# Altium schematic sheet Power_Supply.SchDoc(Power_Supply)
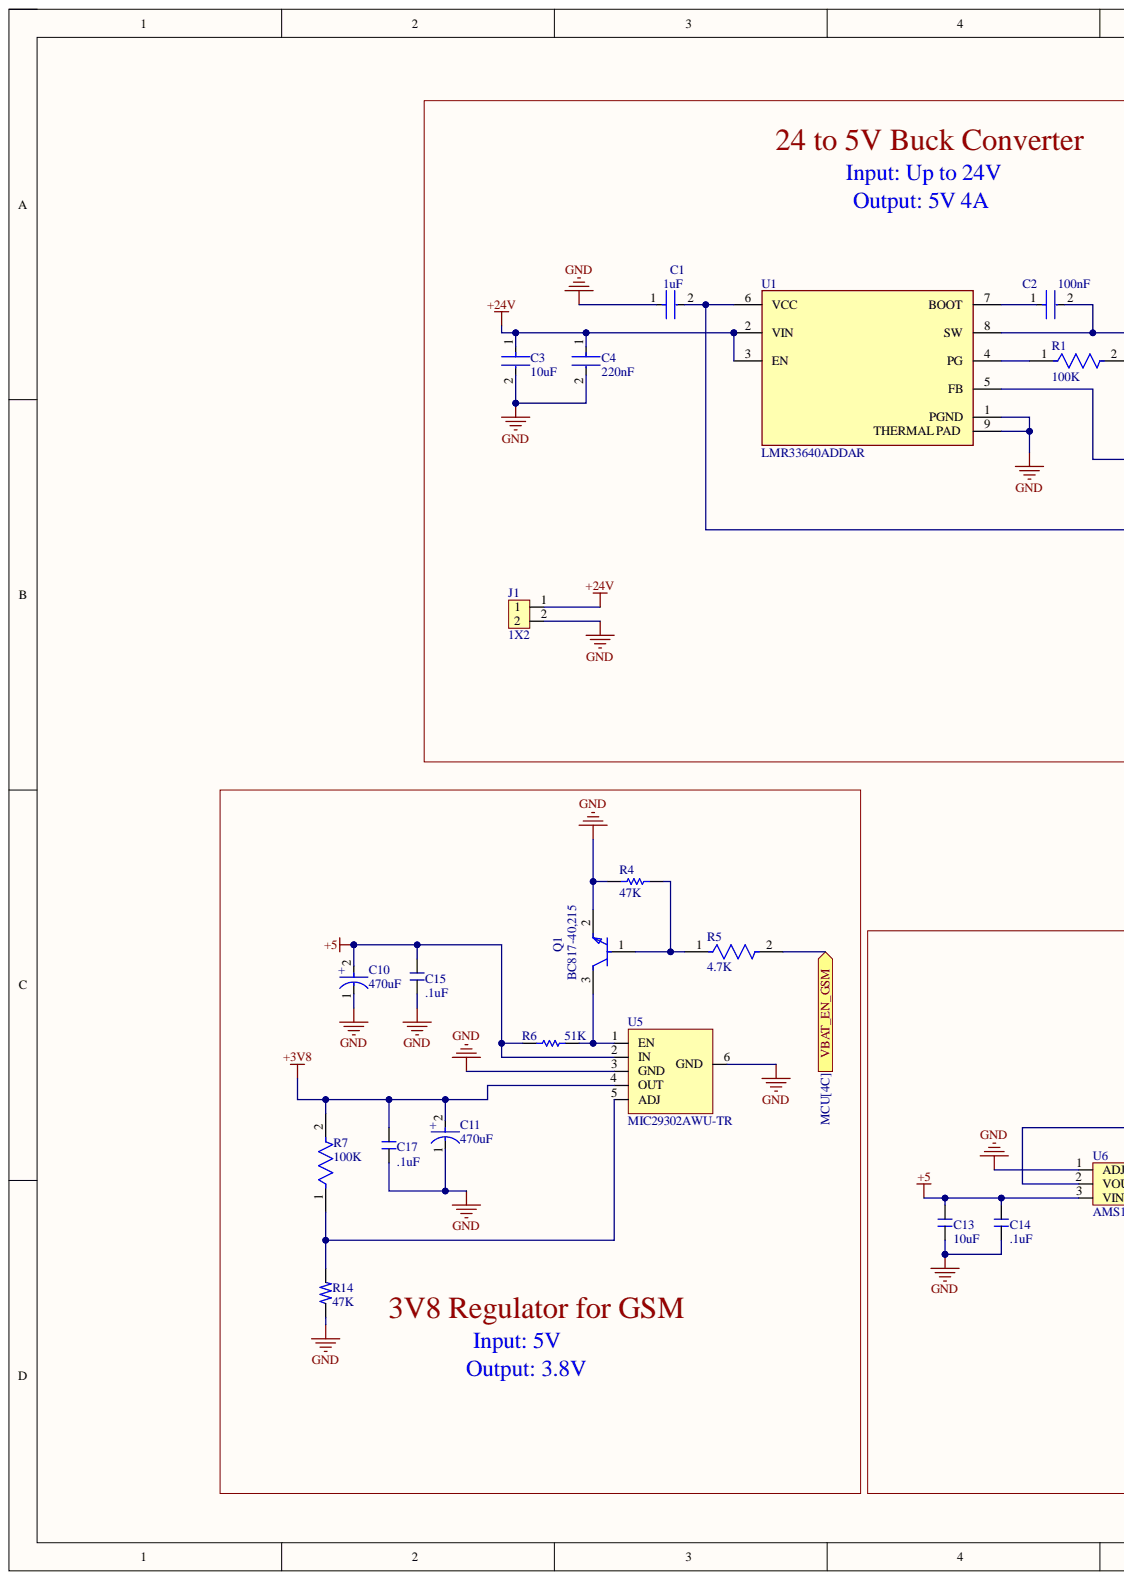
[diagram p0: Power_Supply.SchDoc, left]
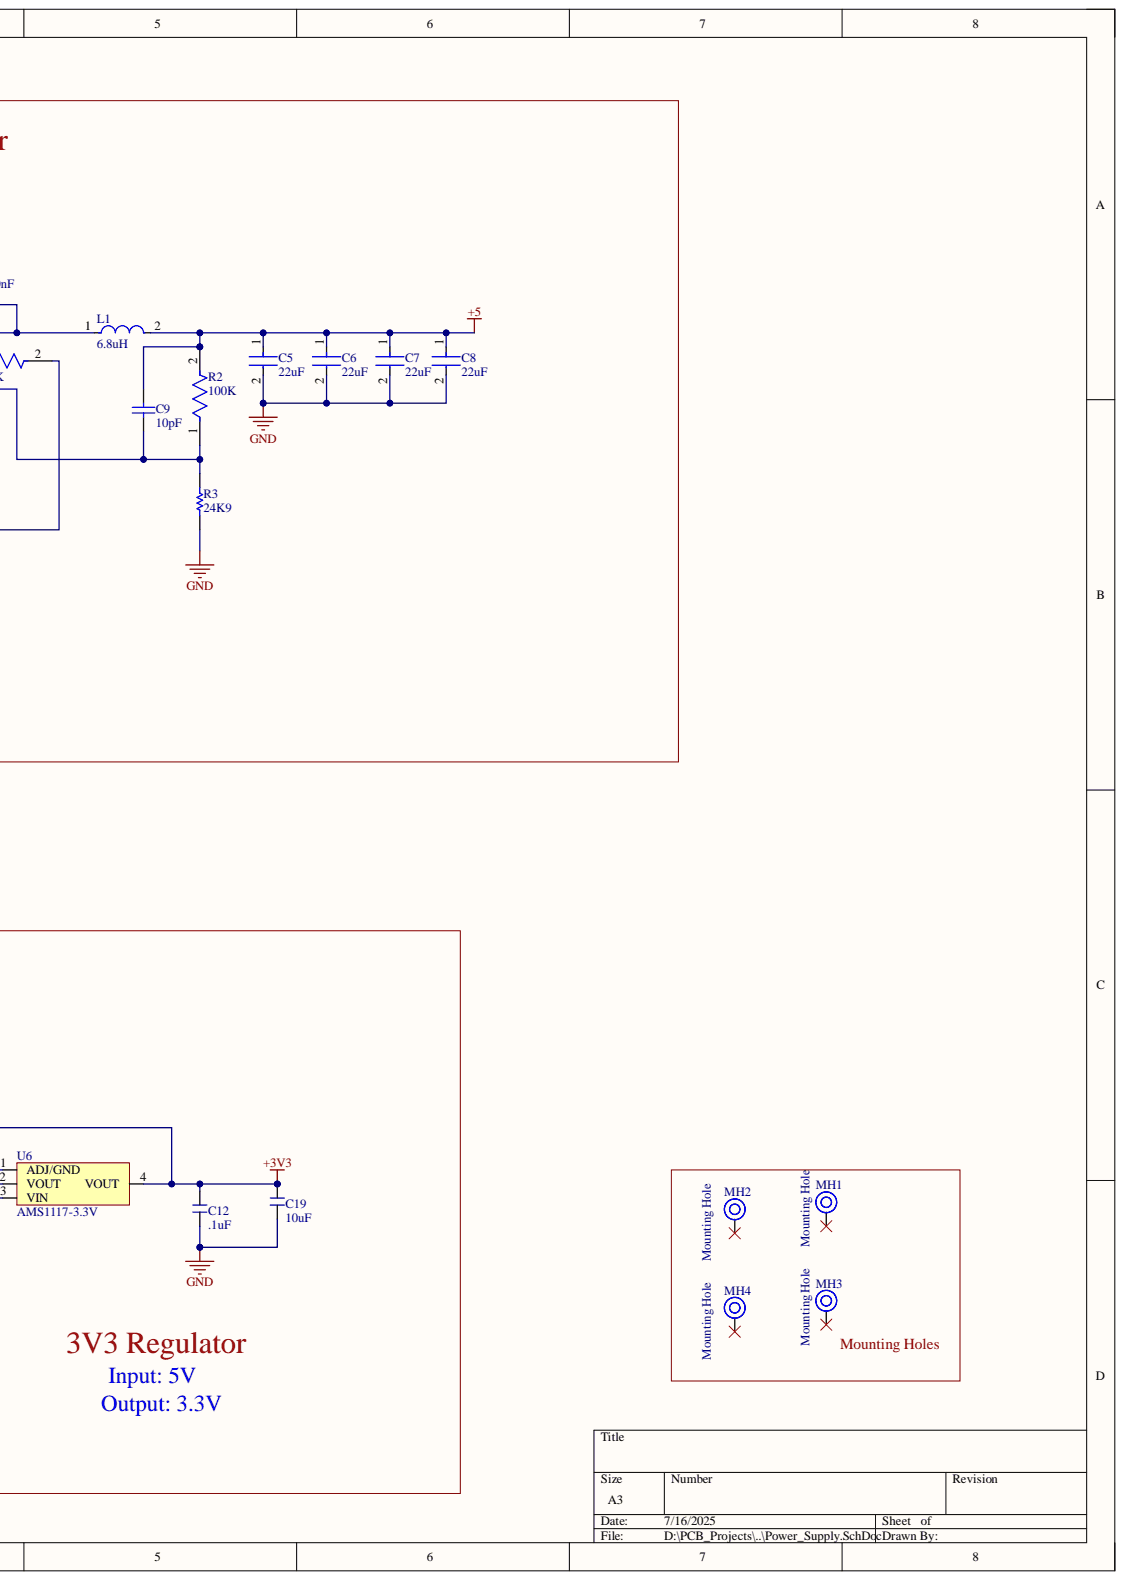
[diagram p1: Power_Supply.SchDoc, right]

## Parts
C1  1uF  [FP-CL21-IPC_C]
C2  100nF  [FP-CC0603-DA-MFG]
C3  10uF  [FP-CL31-IPC_C]
C4  220nF  [FP-C0805C-DN-MFG]
C5  22uF  [FP-CL21-IPC_C]
C6  22uF  [FP-CL21-IPC_C]
C7  22uF  [FP-CL21-IPC_C]
C8  22uF  [FP-CL21-IPC_C]
C9  10pF  [CAPC1608X90X40ML10T20]
C10  470uF  [FP-T491D477K006AT-MFG]
C11  470uF  [FP-T491D477K006AT-MFG]
C12  .1uF  [FB_0805]
C13  10uF  [Capacitor_0805]
C14  .1uF  [FB_0805]
C15  .1uF  [FB_0805]
C17  .1uF  [FB_0805]
C19  10uF  [Capacitor_0805]
J1  1X2  [Header 1x2 2.54mm]
L1  6.8uH  [FP-IHLP-2525CZ-FP_2_413x3-MFG]
MH1  Mounting Hole  [MOUNTING]
MH2  Mounting Hole  [MOUNTING]
MH3  Mounting Hole  [MOUNTING]
MH4  Mounting Hole  [MOUNTING]
Q1  BC817-40,215  [FP-SOT23-MFG]
R1  100K  [FP-RT0805-MFG]
R2  100K  [FP-RT0805-MFG]
R3  24K9  [RESC0805(2012)_L]
R4  47K  [RESC2013X60X35NL10T20]
R5  4.7K  [FP-CRCW0805-e3-IPC_B]
R6  51K  [RESC2013X50X30NL20T20]
R7  100K  [FP-RT0805-MFG]
R14  47K  [Resistor_0805]
U1  LMR33640ADDAR  [FP-DDA0008J-IPC_A]
U5  MIC29302AWU-TR  [TO-263-5]
U6  AMS1117-3.3V  [SOT-223]

## Ports
VBAT_EN_GSM

## Nets
+3V3: C12.1 C19.1 U6.2(VOUT) U6.4(VOUT)
+3V8: C11.2 C17.1 R7.2 U5.4(OUT)
+5: C5.1 C6.1 C7.1 C8.1 C9.2 C10.2 C13.1 C14.1 C15.1 L1.2 R2.2 R6.2 U5.2(IN) U6.3(VIN)
+24V: C3.1 C4.1 J1.1 U1.2(VIN) U1.3(EN)
GND: C1.1 C3.2 C4.2 C5.2 C6.2 C7.2 C8.2 C10.1 C11.1 C12.2 C13.2 C14.2 C15.2 C17.2 C19.2 J1.2 Q1.2 R3.1 R4.1 R14.1 U1.1(PGND) U1.9(THERMAL_PAD) U5.3(GND) U5.6(GND) U6.1(ADJ/GND)
VBAT_EN_GSM: R5.2 ; port VBAT_EN_GSM
NetC1_2: C1.2 R1.2 U1.6(VCC)
NetC2_1: C2.1 U1.7(BOOT)
NetC2_2: C2.2 L1.1 U1.8(SW)
NetC9_1: C9.1 R2.1 R3.2 U1.5(FB)
NetQ1_1: Q1.1 R4.2 R5.1
NetQ1_3: Q1.3 R6.1 U5.1(EN)
NetR1_1: R1.1 U1.4(PG)
NetR7_1: R7.1 R14.2 U5.5(ADJ)

Not connected: MH1 1; MH2 1; MH3 1; MH4 1

## Library data of the parts
C1 = CMP-13271-001433-1: CL21 Series 0805 1uF 50V ±10% Tolerance X7R Multilayer Ceramic Chip Capacitor; Capacitance: 1uF; Case Code (Imperial): 0805; Case Code (Metric): 2012; Case/Package: 0805; Component Kind: Standard; Contact Plating: Tin; Depth: 1.25mm; Dielectric: X7R; Length: 2mm; Library Name: Altium Content Vault; Material: Ceramic; Max Operating Temperature: 125°C; Min Operating Temperature: -55°C; Mount: Surface Mount; Package Quantity: 3000; Packaging: Tape and Reel; Termination: Wraparound; Thickness: 0.053inch; Tolerance: 10%; Voltage: 50V; Voltage Rating: 50V; Width: 0.049inch
C2 = CMP-2100-03601-2: CAP CER 0.1UF 50V X7R 0603; Manufacturer: YAGEO; Manufacturer Part Number: CC0603KRX7R9BB104; Material: Ceramic; Temperature Coefficient: 15%; Tolerance: 0.1; Automotive: No; Capacitance: 100 nF; Category: Cap; Component Kind: Standard; ComponentLink2Description: Datasheet; ComponentLink2URL: http://www.yageo.com/documents/recent/UPY-GPHC_X7R_6.3V-to-50V_18.pdf; Device Class L1: Passive Components; Device Class L2: Capacitors; Device Class L3: Ceramic Capacitors; Digikey Description: CAP CER 0.1UF 50V X7R 0603; Footprint Url: http://www.yageo.com/exep/pages/download/literatures/UPY-C_GEN_24.pdf; Height: 0.9mm; Lead Free: Yes; Library Name: Altium Content Vault; Mouser Description: Multilayer Ceramic Capacitor, CC Series, 0.1 - F, - 10%, X7R, 50 V, 0603 [1608 Metric]; Octopart: https://octopart.com/cc0603krx7r9bb104-yageo-42244635; Package: CC0603-DA; RoHS: TRUE; Temperature: -55°C to +125°C; Temperature Characteristic: X7R; Temperature Range High: +125°C; Temperature Range Low: -55°C; Value: 100 nF; Voltage: 50 V; Voltage Rating: 50 V
C3 = CMP-13271-000016-1: CAP CER 10UF 50V X5R 1206; Manufacturer: Samsung; Manufacturer Part Number: CL31A106KBHNNNE; Material: Ceramic; Temperature Coefficient: 15%; Tolerance: 10%; Automotive: No; Capacitance: 10uF; Category: Cap; Component Kind: Standard; ComponentLink2Description: Datasheet; ComponentLink2URL: http://www.samsungsem.com/kr/support/product-search/mlcc/__icsFiles/afieldfile/2018/06/21/CL31A106KBHNNNE.pdf; Device Class L1: Passive Components; Device Class L2: Capacitors; Device Class L3: Ceramic Capacitors; Digikey Description: CAP CER 10UF 50V X5R 1206; Height: 1.8mm; Ipc Land Pattern Name: CAPC320160X160; Lead Free: Yes; Library Name: Altium Content Vault; Octopart: https://octopart.com/cl31a106kbhnnne-samsung-19832778; Package: CL31; RoHS: TRUE; Temperature: -55°C to +85°C; Temperature Characteristic: X5R; Temperature Range High: +85°C; Temperature Range Low: -55°C; Value: 10uF; Voltage: 50V; Voltage Rating: 50V
C4 = CMP-1036-04552-2: CAP CER 0.22UF 50V X7R 0805; Manufacturer: KEMET; Manufacturer Part Number: C0805C224K5RACTU; Capacitance: 0.22 μF; Material: Ceramic; Temperature Coefficient: 30ppm/°C; Tolerance: 0.1; Value: 0.22 μF; Automotive: No; Category: Cap; Component Kind: Standard; ComponentLink2Description: Datasheet; ComponentLink2URL: https://content.kemet.com/datasheets/KEM_C1003_C0G_SMD.pdf; Device Class L1: Passive Components; Device Class L2: Capacitors; Device Class L3: Ceramic Capacitors; Digikey Description: CAP CER 0.22UF 50V X7R 0805; Height: 0.88mm; Ipc Land Pattern Name: CAPC200125X78; Lead Free: Yes; Library Name: Altium Content Vault; Mouser Description: CAP CER 0.22UF 50V X7R 0805; Octopart: https://octopart.com/c0805c224k5ractu-kemet-144670; Package: C0805C-DN; RoHS: TRUE; Temperature: -55°C to +125°C; Temperature Characteristic: X7R; Temperature Range High: +125°C; Temperature Range Low: -55°C; Voltage Rating: 50 V
C5-C8 = CMP-13271-002990-1: CL21 Series 0805 22uF 16V ±20% Tolerance X5R Multilayer Ceramic Chip Capacitor; Capacitance: 22uF; Case Code (Imperial): 0805; Case Code (Metric): 2012; Case/Package: 0805; Component Kind: Standard; Length: 0.079inch; Library Name: Altium Content Vault; Max Operating Temperature: 85°C; Min Operating Temperature: -55°C; Mount: Surface Mount; Packaging: Tape and Reel; Thickness: 0.037inch; Tolerance: 20%; Voltage Rating: 16V; Width: 0.049inch
C9 = CMP-2100-03593-1: Chip Capacitor, 10 pF, +/- 5%, 50 V, -55 to 125 degC, 0603 (1608 Metric), RoHS, Tape and Reel; Manufacturer: Yageo; Dielectric: C0G; Insulation Resistance: 100GR; Temperature Coefficient: 30ppm/degC; Voltage: 50V; Capacitance: 10pF; Case Code (Imperial): 0603; Case Code (Metric): 1608; Case/Package: 0603; Component Kind: Standard; Composition: Ceramic; Datasheet URL: http://www.yageo.com/documents/recent/UPY-GP_NP0_16V-to-50V_14.pdf; Datasheet Version: Rev. 14, 07/2016; Depth: 0.8mm; Height: 0.8mm; Lead Free: LeadFree; Length: 1.6mm; Library Name: Altium Content Vault; Manufacturer URL: http://www.yageo.com/; Max Operating Temperature: 125degC; Min Operating Temperature: -55degC; Mount: SurfaceMount; Number of Pins: 2; Package Description: 2-Pin Surface Mount Device, Body 1.6 x 0.8 mm; Package Quantity: 1; Package Reference: 0603; Packaging: TapeandReel; Radiation Hardening: No; REACH SVHC: NoSVHC; RoHSCompliant: True; Termination: SMD/SMT; Thickness: 0.035inch; Tolerance: 5%; Voltage Rating: 50V; Voltage Rating (DC): 50V; Width: 0.031inch
C10 C11 = CMP-03017-000053-1: CAP TANT 470UF 10% 6.3V 2917; Dielectric Material: Tantalum; Dissipation Factor: 12%; ESR (Equivalent Series Resistance): 400mR; Leakage Current: 19.8uA; Life (Hours): 2000Hour; Polarity: Polar; Ripple Current: 612mA; Capacitance: 470uF; Case Code (Imperial): 2917; Case Code (Metric): 7343; Case/Package: 2917; Component Kind: Standard; Construction: Flat; Depth: 4.3mm; Failure Rate: 0.001%; Features: General Purpose; Height: 3.1mm; Height - Seated (Max): 0.122inch; Lead Free: Lead Free; Length: 7.3mm; Library Name: Altium Content Vault; Max Operating Temperature: 125°C; Min Operating Temperature: -55°C; Mount: Surface Mount; Number of Pins: 2; Package Quantity: 1; Packaging: Tape and Reel; Radiation Hardening: No; REACH SVHC: No SVHC; RoHS Compliant: Yes; Series: T491; Termination: SMD/SMT; Tolerance: 10%; Voltage Rating: 6.3V; Voltage Rating (DC): 6.3V; Weight: 0.023634oz; Width: 0.169inch
C12 C14 C15 C17 = CAP .1 UF: Cap Ceramic 0.1uF 100V X7R 10% Pad SMD 0805 Fail Safe with Soft Termination 125C Automotive T/R; Manufacturer: Murata; Manufacturer Part Number: GCE21BR72A104KA01L; Material: 4241ConductorMaterial; Value: 100nF; Case Code (Imperial): 0805; Case Code (Metric): 2012; Case/Package: 0805; Category: Ceramic Capacitors; Component Kind: Standard; ComponentLink1Description: http://search.murata.co.jp/Ceramy/image/img/A01X/partnumbering_e_02.pdf?src-supplier=Digikey; ComponentLink1URL: http://search.murata.co.jp/Ceramy/image/img/A01X/partnumbering_e_02.pdf?src-supplier=Digikey; ComponentLink2Description: http://search.murata.co.jp/Ceramy/image/img/A01X/G101/ENG/GCE21BR72A104KA01-01.pdf?src-supplier=Digikey; ComponentLink2URL: http://search.murata.co.jp/Ceramy/image/img/A01X/G101/ENG/GCE21BR72A104KA01-01.pdf?src-supplier=Digikey; Features: Soft Termination; Height: 1.45mm; Lead Free: Lead Free; Length: 2mm; Library Name: Schlib1.SchLib; Max Operating Temperature: 125°C; Min Operating Temperature: -55°C; Mounting Technology: Surface Mount; Packaging: Cut Tape; Pricing: 1=0,25, 10=0,152, 50=0,152, 100=0,1, 1000=0,073, 10000=0,055 (USD); Ratings: AEC-Q200; RoHS: Yes; RoHS Compliant: Yes; Series: GCE; Stock: 45477; Supplier: Mouser; Supplier Part Number: 81-GCE21BR72A104KA1L; Thickness: 1.25mm; Tolerance: 10%; Voltage Rating: 100V; Width: 1.25mm
C13 C19 = CAP 10uF 0805: 16V 10uF X7R ±10% 0805 Multilayer Ceramic Capacitors MLCC - SMD/SMT ROHS; Manufacturer: Samsung; Manufacturer Part Number: CL21B106KOQNNNE; Material: 4241ConductorMaterial; Case Code (Imperial): 0805; Case Code (Metric): 2012; Case/Package: 0805; Category: Ceramic Capacitors; Component Kind: Standard; ComponentLink1Description: https://datasheet.ciiva.com/25794/cl21b106koqnnne-25794472.pdf?src-supplier=Digikey; ComponentLink1URL: https://datasheet.ciiva.com/25794/cl21b106koqnnne-25794472.pdf?src-supplier=Digikey; ComponentLink2Description: https://datasheet.ciiva.com/16428/cl21b106koqnnne-16428887.pdf?src-supplier=Future+Electronics; ComponentLink2URL: https://datasheet.ciiva.com/16428/cl21b106koqnnne-16428887.pdf?src-supplier=Future+Electronics; ComponentLink3Description: https://datasheet.ciiva.com/26920/getdatasheetpartid-355170-26920201.pdf?src-supplier=Verical; ComponentLink3URL: https://datasheet.ciiva.com/26920/getdatasheetpartid-355170-26920201.pdf?src-supplier=Verical; Construction: Flat; Depth: 1.25mm; Dielectric: X7R; Height: 1.25mm; Length: 2mm; Library Name: Schlib1.SchLib; Max Operating Temperature: 125°C; Min Operating Temperature: -55°C; Mounting Technology: Surface Mount; Package Quantity: 1; Packaging: Tape and Reel; Pricing: 1=0,09, 10=0,037, 100=0,0354 (USD); Radiation Hardening: No; RoHS: Yes; RoHS Compliant: Yes; Series: CL; Stock: 20461; Supplier: Digikey; Supplier Part Number: 1276-2872-1-ND; Thickness: 0.055inch; Tolerance: 10%; Value: 10uF; Voltage: 16V; Voltage Rating: 16V; Voltage Rating (DC): 16V; Width: 0.049inch
J1 = Header 1X2 2.54 mm: Conn Unshrouded Header HDR 2 POS 2.54mm Solder ST Thru-Hole Automotive Carton; Manufacturer: TE Connectivity; Manufacturer Part Number: 826629-2; Contact Style: 4753ConnectorType; Current Rating: 5A; Gender: 29Gender; Insulation Resistance: 5GR; Number of Contacts: 2NUMBER; Category: Headers and Wire Housings; Color: 49Colour; Component Kind: Standard; ComponentLink1Description: https://www.te.com/commerce/DocumentDelivery/DDEController?Action=srchrtrv&amp;DocNm=826629&amp;DocType=Customer+Drawing&amp;DocLang=English&src-supplier=Digikey; ComponentLink1URL: https://www.te.com/commerce/DocumentDelivery/DDEController?Action=srchrtrv&amp;DocNm=826629&amp;DocType=Customer+Drawing&amp;DocLang=English&src-supplier=Digikey; ComponentLink2Description: https://datasheet.octopart.com/826629-2-TE-Connectivity---AMP-datasheet-17024315.pdf?src-supplier=Element14; ComponentLink2URL: https://datasheet.octopart.com/826629-2-TE-Connectivity---AMP-datasheet-17024315.pdf?src-supplier=Element14; ComponentLink3Description: https://datasheet.octopart.com/826629-2-TE-Connectivity---AMP-datasheet-179310416.pdf?src-supplier=Farnell; ComponentLink3URL: https://datasheet.octopart.com/826629-2-TE-Connectivity---AMP-datasheet-179310416.pdf?src-supplier=Farnell; ComponentLink4Description: https://datasheet.octopart.com/826629-2-TE-Connectivity---AMP-datasheet-44414285.pdf?src-supplier=Electro+Sonic; ComponentLink4URL: https://datasheet.octopart.com/826629-2-TE-Connectivity---AMP-datasheet-44414285.pdf?src-supplier=Electro+Sonic; ComponentLink5Description: https://datasheet.octopart.com/826629-2-TE-Connectivity-datasheet-181566106.pdf?src-supplier=Sager+Electronics; ComponentLink5URL: https://datasheet.octopart.com/826629-2-TE-Connectivity-datasheet-181566106.pdf?src-supplier=Sager+Electronics; Contact Plating: 154,157ConductorMaterial; Height: 10mm; Housing Color: 49Colour; Housing Material: 175ConductorMaterial; Lead Free: 5697LeadFree; Lead Pitch: 2.54mm; Library Name: Schlib1.SchLib; Orientation: 5495OrientationType; Pins: 2NUMBER; Pricing: 1=0,15044, 10=0,14915, 30=0,14703, 50=0,14491, 100=0,14279 (USD); RoHS: Yes; RoHS Compliant: 5897YesNo; Row Spacing: 2.54mm; Stock: 21749; Supplier: Avnet; Supplier Part Number: 826629-2
L1 = CMP-02424-000391-1: Automotive Inductors, High Saturation Series 6.8uH 4.5A 60mΩ 20%; DC Resistance (DCR): 60mR; Resistance: 0.06R; Shielding: Shielded; Test Frequency: 100kHz; Case/Package: 2525; Component Kind: Standard; Depth: 6.72mm; Inductance: 6.8uH; Lead Free: Lead Free; Length: 7.24mm; Library Name: Altium Content Vault; Max Operating Temperature: 125°C; Military Standard: Not; Min Operating Temperature: -55°C; Packaging: Tape and Reel; Tolerance: 20%; Width: 6.48mm
MH1-MH4 = MH_3.5H_6D: Alternate Part Number-1: NA; Case/Package: TH; Component Kind: Standard; Description: Mounting Hole; Library Name: Schlib1.SchLib; Manufacturer: NA; Manufacturer Part Number: NA; Supplier Part Number-1: NA; Supplier-1: NA
Q1 = CMP-14406-000007-1: TRANS NPN 45V 0.5A SOT23; Manufacturer: Nexperia; Automotive Grade: Grade 0; Collector Base Voltage: 50V; Collector Emitter Saturation Voltage: 700mV; Emitter Base Voltage: 5V; Hfe Max: 600; Hfe Min: 250; Hfe Testic: 100mA; Hfe Testvce: 1V; Max Collector Current: 500mA; Max Junction Temp: +150°C; Number Of Elements: 1; Power Dissipation: 250mW; Rthja Max: 500K/W; Unity Gain Freq: 100MHz; Ambient Temperature Range High: +150°C; Ambient Temperature Range Low: -65°C; Automotive: Yes; Category: Trans; Collector Emitter Breakdown Voltage: 45V; Collector Emitter Voltage: 45V; Component Kind: Standard; ComponentLink2Description: Datasheet; ComponentLink2URL: https://assets.nexperia.com/documents/data-sheet/BC817_SER.pdf; Device Class L1: Discrete Semiconductors; Device Class L2: Transistors; Device Class L3: BJTs; Digikey Description: TRANS NPN 45V 0.5A SOT23; Footprint Url: https://assets.nexperia.com/documents/outline-drawing/SOT23.pdf; Height: 1.1mm; Ipc Land Pattern Name: SOT95P230X100-3; Lead Free: Yes; Library Name: Altium Content Vault; Manufacturer Part Number: BC817-40,215; Mouser Description: BC817 Series 45 V 500 mA 250 mW SMT NPN General-Purpose Transistor - SOT-23-; Number Of Npn: 1; Octopart: https://octopart.com/bc817-40%2C215-nexperia-78739081; Package: SOT23; RoHS: TRUE; Temperature: to +150°C; Temperature Range High: +150°C
R1 R2 R7 = CMP-2001-01711-2: RES SMD 100K OHM 0.1% 1/8W 0805; Manufacturer: Yageo; Manufacturer Part Number: RT0805BRD07100KL; Power Rating: 0.125W; Resistance: 100KΩ; Temperature Coefficient: 25 ppm/°C; Tolerance: 0.0025; Value: 100KΩ; Voltage Rating: 150V; Automotive: No; Category: Res; Component Kind: Standard; ComponentLink2Description: Datasheet; ComponentLink2URL: http://www.yageo.com/documents/recent/PYu-RT_1-to-0.01_RoHS_L_9.pdf; Composition: Thin Film; Device Class L1: Passive Components; Device Class L2: Resistors; Device Class L3: Chip SMD Resistors; Digikey Description: RES SMD 100K OHM 0.1% 1/8W 0805; Footprint Url: http://www.yageo.com/exep/pages/download/literatures/PYu-R_Mount_10.pdf; Height: 0.6mm; Ipc Land Pattern Name: RESC200125X50; Lead Free: Yes; Library Name: Altium Content Vault; Mouser Description: Thin Film Resistors - SMD 100K ohm 0.1% 1/8W; Octopart: https://octopart.com/rt0805brd07100kl-yageo-1388347; Package: RT0805; RoHS: TRUE; Series: RT; Temperature: -55°C to +155°C; Temperature Range High: +155°C; Temperature Range Low: -55°C
R3 = CMP-1013-00666-1: 24K9 0.125W 1% 0805 (2012 Metric)  SMD; Case-EIA: 0805; Case-Metric: 2012; Value: 24900.00 Ohm; Component Kind: Standard; Library Name: Altium Content Vault; Power: 0.125 W; Technology: SMT; Tolerance: 1 %
R4 = CMP-1659-00011-4: Chip Resistor, 47 KOhm, +/- 1%, 0.125 W, -55 to 155 degC, 0805 (2012 Metric), RoHS, Tape and Reel; Manufacturer: Yageo; Voltage Rating: 150 V; Component Kind: Standard; ComponentLink1Description: Manufacturer URL; ComponentLink1URL: http://www.yageo.com/; ComponentLink2Description: Datasheet; ComponentLink2URL: http://www.yageo.com/documents/recent/PYu-RC_Group_51_RoHS_L_04.pdf; DatasheetVersion: Rev. 4, 02/2016; Height: 0.5 mm; Length: 2 mm; Library Name: Altium Content Vault; Max Operating Temperature: 155 degC; Min Operating Temperature: -55 degC; Mount: Surface Mount; Mounting Technology: Surface Mount; Package Quantity: 200; PackageDescription: 2-Pin Surface Mounted Device, Body 2 x 1.25 mm; PackageReference: 0805; Packaging: Tape and Reel; Pins: 2; REACH SVHC: No SVHC; RoHSCompliant: true
R5 = CMP-2100-03615-2: RES Thick Film, 4.7kΩ, 1%, 0.125W, 100ppm/°C, 0805; Max Power Dissipation: 125mW; Resistance: 4.7kR; Voltage Rating: 150V; Case Code (Imperial): 0805; Case Code (Metric): 2012; Case/Package: 0805; Component Kind: Standard; Composition: Thick Film; Contact Plating: Tin; Depth: 1.25mm; Features: Automotive AEC-Q200; Height: 0.45mm; Lead Free: Lead Free; Length: 2mm; Library Name: Altium Content Vault; Max Operating Temperature: 155°C; Military Standard: Not; Min Operating Temperature: -55°C; Number of Terminations: 2; Package Quantity: 10; Packaging: Tape and Reel; Power Rating: 125mW; Radiation Hardening: No; Temperature Coefficient: 100ppm/°C; Termination: SMD/SMT; Tolerance: 1%; Voltage Rating (DC): 150V; Weight: 0.000194oz; Width: 1.25mm
R6 = CMP-2001-03993-1: Manufacturer: Vishay Dale; Part Number: CRCW080551K0FKEA; Component Kind: Standard; Library Name: Altium Content Vault
R14 = RES 47K 0805: 125Mw Thick Film Resistors 100Ppm/ 1% 47K 0805 Chip Resistor Surface Mount Rohs; Manufacturer: Yageo; Manufacturer Part Number: RC0805FR-0747KL; Temperature Coefficient: 100ppm/°C; Value: 47kR; Voltage Rating: 150V; Case Code (Imperial): 0805; Case Code (Metric): 2012; Case/Package: 0805; Category: Chip SMD Resistors; Component Kind: Standard; ComponentLink1Description: https://datasheet.ciiva.com/7941/1686128-7941799.pdf?src-supplier=Element14; ComponentLink1URL: https://datasheet.ciiva.com/7941/1686128-7941799.pdf?src-supplier=Element14; ComponentLink2Description: https://datasheet.ciiva.com/46447/444164-46447581.pdf?src-supplier=Element14; ComponentLink2URL: https://datasheet.ciiva.com/46447/444164-46447581.pdf?src-supplier=Element14; ComponentLink3Description: https://datasheet.ciiva.com/7941/444164-7941800.pdf?src-supplier=Element14; ComponentLink3URL: https://datasheet.ciiva.com/7941/444164-7941800.pdf?src-supplier=Element14; ComponentLink4Description: https://datasheet.ciiva.com/7941/444164-7941801.pdf?src-supplier=Element14; ComponentLink4URL: https://datasheet.ciiva.com/7941/444164-7941801.pdf?src-supplier=Element14; ComponentLink5Description: https://datasheet.ciiva.com/16742/rc0805-16742265.pdf?src-supplier=Future+Electronics; ComponentLink5URL: https://datasheet.ciiva.com/16742/rc0805-16742265.pdf?src-supplier=Future+Electronics; Contact Plating: Tin,Matte; Features: Moisture Resistant; Height: 0.5mm; Lead Free: 5697LeadFree; Length: 2mm; Library Name: Schlib1.SchLib; Max Operating Temperature: 155°C; Max Power Dissipation: 125mW; Min Operating Temperature: -55°C; Number of Terminations: 2; Packaging: Tape and Reel; Pins: 2; Power Rating: 125mW; Pricing: 5000=0,00212, 10000=0,00209, 20000=0,00206, 30000=0,00203, 40000=0,00202 (USD); Reach SVHC Compliant: Unknown; Resistance Tolerance: 1%; Resistor Type: Thick Film; RoHS: Yes; RoHS Compliant: Yes; Series: RC; Stock: 70000; Supplier: Avnet; Supplier Part Number: RC0805FR-0747KL; Termination: SMD/SMT; Tolerance: 1%; Voltage Rating (AC): 150V; Voltage Rating (DC): 150V; Width: 1.25mm; Working Voltage: 150V
U1 = CMP-04918-000399-1: 36V 4A 400KHZ REGULATOR; Component Kind: Standard; Library Name: Altium Content Vault
U5 = LDO MIC29302AWU-TR: IC REG LDO ADJ 3A TO263-5; Manufacturer: Microchip; Category: Voltage Regulators - Linear; Component Kind: Standard; ComponentLink1Description: https://datasheet.ciiva.com/13026/mic29150-13026090.pdf?src-supplier=Mouser; ComponentLink1URL: https://datasheet.ciiva.com/13026/mic29150-13026090.pdf?src-supplier=Mouser; ComponentLink2Description: https://datasheet.ciiva.com/16467/mic29302a-16467068.pdf?src-supplier=Future+Electronics; ComponentLink2URL: https://datasheet.ciiva.com/16467/mic29302a-16467068.pdf?src-supplier=Future+Electronics; ComponentLink3Description: https://datasheet.ciiva.com/2054/mic29302a-2054246.pdf?src-supplier=Digikey; ComponentLink3URL: https://datasheet.ciiva.com/2054/mic29302a-2054246.pdf?src-supplier=Digikey; ComponentLink4Description: https://datasheet.ciiva.com/2054/mic29302a-2054247.pdf?src-supplier=Digikey; ComponentLink4URL: https://datasheet.ciiva.com/2054/mic29302a-2054247.pdf?src-supplier=Digikey; ComponentLink5Description: https://datasheet.ciiva.com/2054/mic29302a-2054248.pdf?src-supplier=Digikey; ComponentLink5URL: https://datasheet.ciiva.com/2054/mic29302a-2054248.pdf?src-supplier=Digikey; Library Name: Schlib1.SchLib; Manufacturer Part Number: MIC29302AWU-TR; Pricing: 100=1,91, 750=1,87 (EUR); RoHS: Yes; Stock: 814; Supplier: Farnell; Supplier Part Number: 2851564RL
U6 = AMS1117-3.3V: 1A Fixed 3.3V 18V SOT-223 Voltage Regulators - Linear, Low Drop Out (LDO) Regulators ROHS; Manufacturer: Tech Public; Category: LDO; Component Kind: Standard; Library Name: Schlib1.SchLib; Manufacturer Part Number: AMS1117-3.3V; Pricing: 10=0,0402, 100=0,0315, 300=0,0271, 1000=0,0239, 4000=0,0213, 8000=0,02 (USD); RoHS: No; Stock: 2690; Supplier: LCSC; Supplier Part Number: C20611856
